# Altium schematic sheet SD.SchDoc(SD)
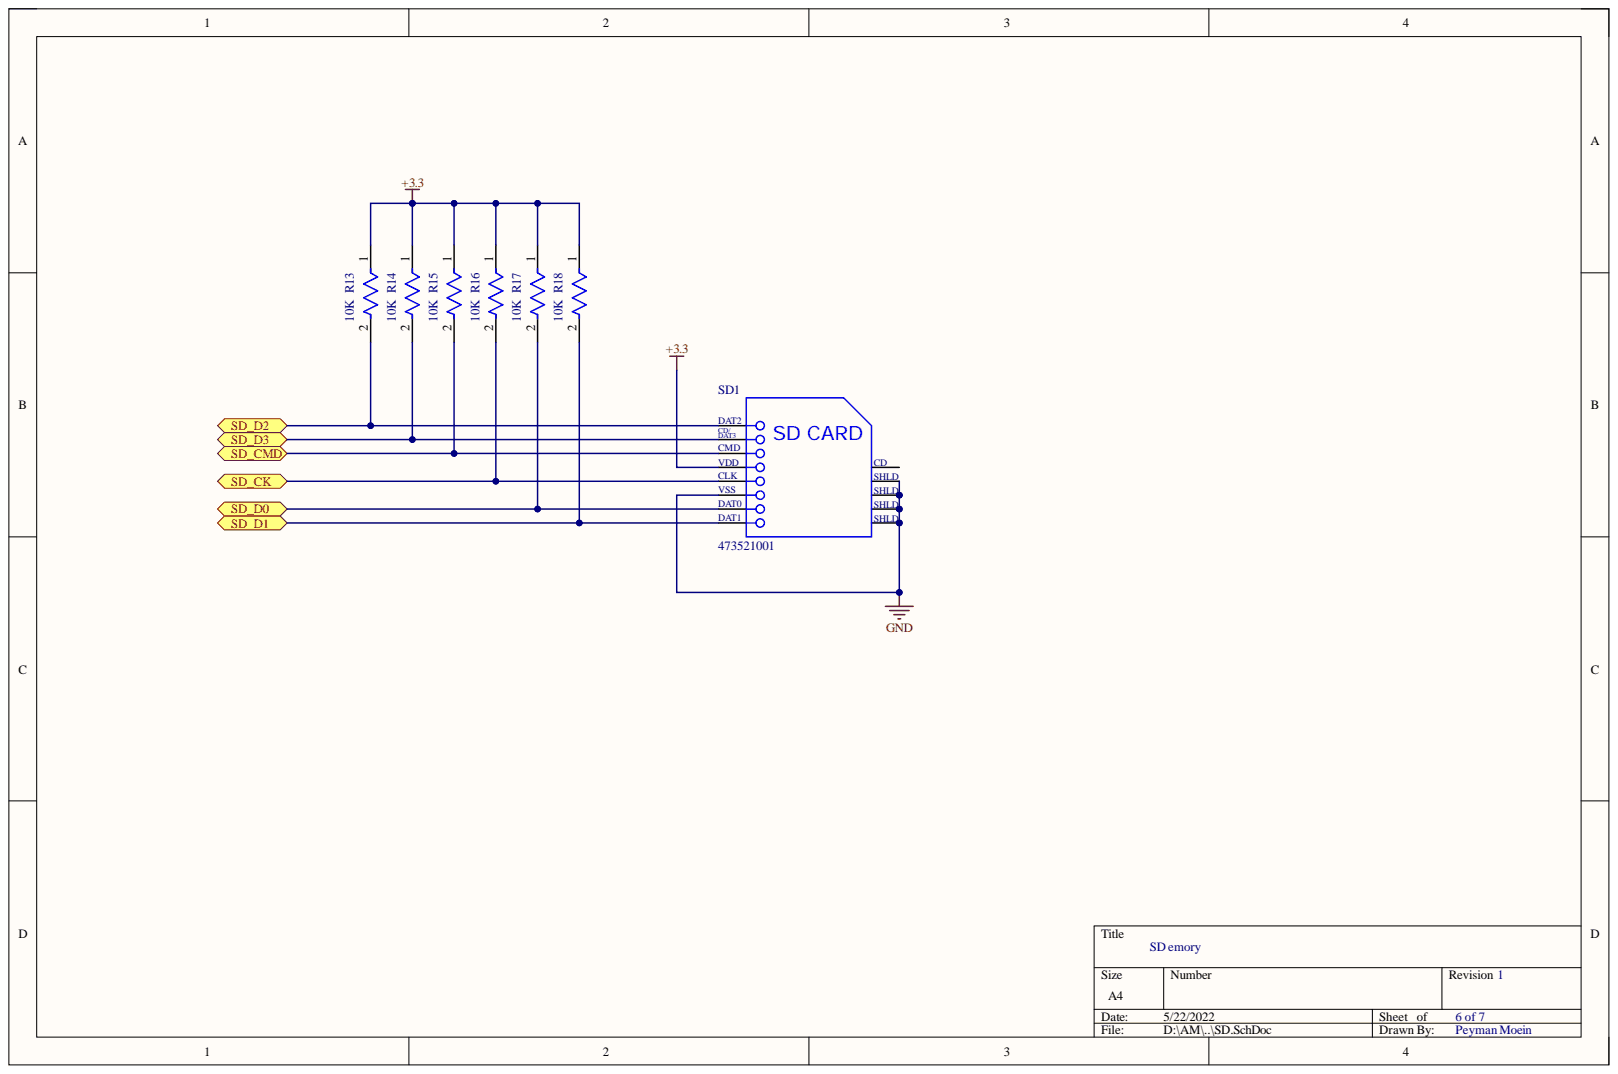
[diagram p0: SD.SchDoc]

## Parts
R13  10K  [FP-CRCW0805-e3-MFG]
R14  10K  [FP-CRCW0805-e3-MFG]
R15  10K  [FP-CRCW0805-e3-MFG]
R16  10K  [FP-CRCW0805-e3-MFG]
R17  10K  [FP-CRCW0805-e3-MFG]
R18  10K  [FP-CRCW0805-e3-MFG]
SD1  473521001  [MOLX-473521001_V]

## Ports
SD_CK, SD_CMD, SD_D0, SD_D1, SD_D2, SD_D3

## Nets
+3.3: R13.1 R14.1 R15.1 R16.1 R17.1 R18.1 SD1.4
GND: SD1.6 SD1.10 SD1.11 SD1.12 SD1.13
SD_CK: R16.2 SD1.5 ; port SD_CK
SD_CMD: R15.2 SD1.3 ; port SD_CMD
SD_D0: R17.2 SD1.7 ; port SD_D0
SD_D1: R18.2 SD1.8 ; port SD_D1
SD_D2: R13.2 SD1.1(SD_CARD) ; port SD_D2
SD_D3: R14.2 SD1.2 ; port SD_D3

Not connected: SD1 9

## Library data of the parts
R13-R18 = CMP-02407-004193-1: RES Thick Film, 2.94Ω, 1%, 0.125W, 100ppm/°C, 0805; Max Power Dissipation: 125mW; Resistance: 2.94R; Voltage Rating: 150V; Case Code (Imperial): 0805; Case Code (Metric): 2012; Case/Package: 0805; Component Kind: Standard; Composition: Thick Film; Contact Plating: Tin; Depth: 1.25mm; Features: Automotive AEC-Q200; Height: 0.02inch; Lead Free: Lead Free; Length: 2mm; Library Name: Altium Content Vault; Max Operating Temperature: 155°C; Military Standard: Not; Min Operating Temperature: -55°C; Number of Terminations: 2; Package Quantity: 10; Packaging: Tape and Reel; Power Rating: 125mW; Radiation Hardening: No; Temperature Coefficient: 100ppm/°C; Termination: SMD/SMT; Tolerance: 1%; Weight: 0.000194oz; Width: 0.049inch
SD1 = CMP-2000-05848-1: MicroSD(TM), Pitch 1.1 mm, 8 Position, Height 1.88 mm, -20 to 85 degC, RoHS, Tape and Reel; Manufacturer: Molex; Component Kind: Standard; Datasheet URL: http://www.molex.com/pdm_docs/sd/473521001_sd.pdf; Datasheet Version: Rev. G, 01/2012; Library Name: Altium Content Vault; Manufacturer URL: http://www.molex.com/; Mounting Technology: SurfaceMount; Package Description: MicroSD(TM), Pitch 1.1 mm, 8 Position, Height 1.88 mm; Package Reference: 473521001
